# Altium schematic sheet Capybara.SchDoc
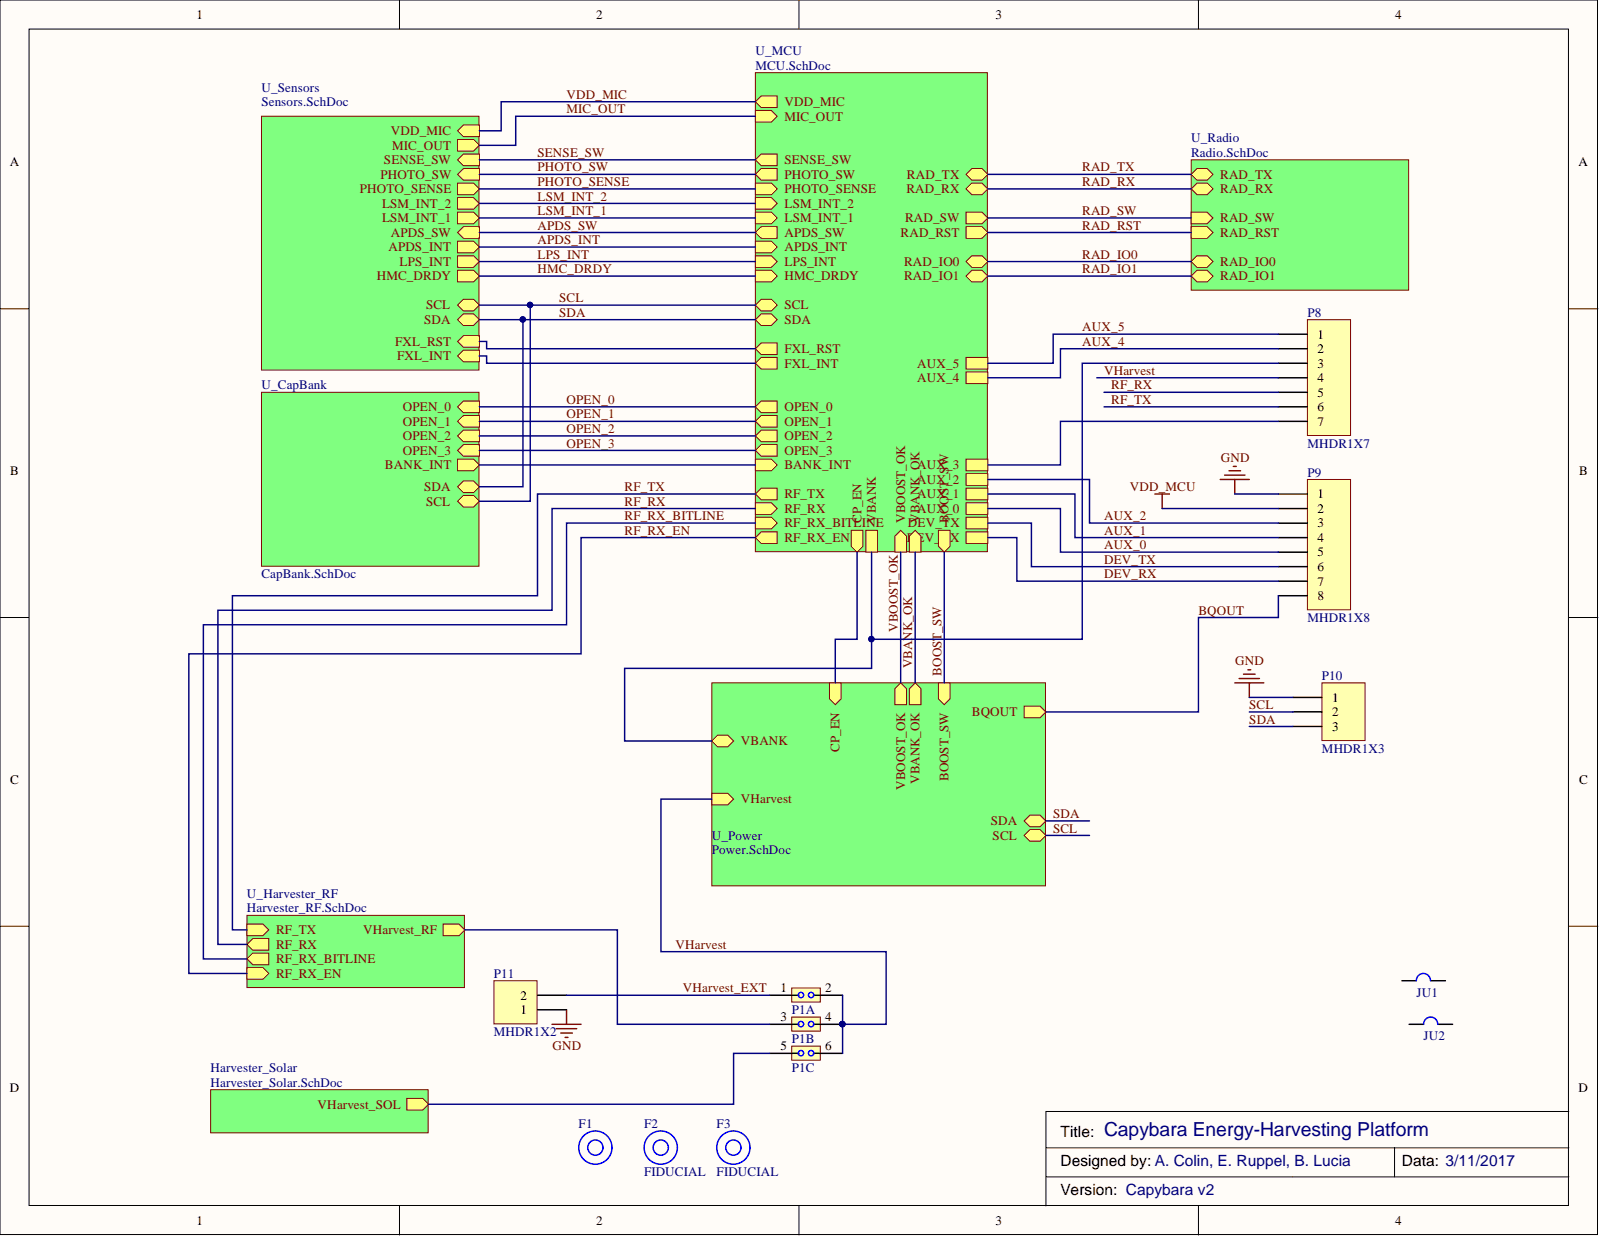
[diagram p0: Capybara.SchDoc]

## Parts
F1  FIDUCIAL  [FIDUCIAL]
F2  FIDUCIAL  [FIDUCIAL]
F3  FIDUCIAL  [FIDUCIAL]
JU1  JUMPER  [JUMPER0603]  (value not drawn)  (jumper)
JU2  JUMPER  [JUMPER0603]  (value not drawn)  (jumper)
P1  JMPHDR_12  [MHDR2X12]  (value not drawn)  (parts A,B,C)
P8  MHDR1X7  [MHDR1X7]
P9  MHDR1X8  [MHDR1X8]
P10  MHDR1X3  [MHDR1X3]
P11  MHDR1X2  [MHDR1X2]

## Nets
GND: P9.1 P10.1 P11.1
VDD_MCU: P9.2
AUX_0: P9.5
AUX_1: P9.4
AUX_2: P9.3
AUX_4: P8.2
AUX_5: P8.1
BQOUT: P9.8
DEV_RX: P9.7
DEV_TX: P9.6
RF_RX: P8.5
RF_TX: P8.6
SCL: P10.2
SDA: P10.3
VHarvest: P1.2 P1.4 P1.6 P8.4
VHarvest_EXT: P1.1 P11.2
NetJU1_1: JU1.1
NetJU1_2: JU1.2
NetJU2_1: JU2.1
NetJU2_2: JU2.2
NetP1_3: P1.3
NetP1_5: P1.5
NetP8_3: P8.3
NetP8_7: P8.7
APDS_INT:
APDS_SW:
BANK_INT:
BOOST_SW:
CP_EN:
FXL_INT:
FXL_RST:
HMC_DRDY:
LPS_INT:
LSM_INT_1:
LSM_INT_2:
MIC_OUT:
OPEN_0:
OPEN_1:
OPEN_2:
OPEN_3:
PHOTO_SENSE:
PHOTO_SW:
RAD_IO0:
RAD_IO1:
RAD_RST:
RAD_RX:
RAD_SW:
RAD_TX:
RF_RX_BITLINE:
RF_RX_EN:
SENSE_SW:
VBANK_OK:
VBOOST_OK:
VDD_MIC:

## Library data of the parts
P1 = JMPHDR_12: Manufacturer: Sullins Connector Solutions; Manufacturer Part Number: GRPB122VWVN-RC; Component Kind: Standard; Digi-Key Part Number: S9015E-12-ND; Distributor: Digikey; Distributor Part Number: S9015E-12-ND; Library Name: Sprite.SCHLIB
P8 = MHDR1X7: Header, 7-Pin; Manufacturer: Harwin Inc.; Manufacturer Part Number: M52-5000745; Component Kind: Standard; Digi-Key Part Number: 952-3360-ND; Distributor: Mouser; Distributor Part Number: 855-M52-5000745; LatestRevisionDate: 17-Jul-2002; LatestRevisionNote: Re-released for DXP Platform.; Library Name: Miscellaneous Connectors.IntLib; Mouser Part Number: 855-M52-5000745; Publisher: Altium Limited
P9 = MHDR1X8: Header, 8-Pin; Manufacturer: Harwin Inc.; Manufacturer Part Number: M52-5000845; Component Kind: Standard; Digi-Key Part Number: 952-3361-ND; Distributor: Mouser; Distributor Part Number: 855-M52-5000845; LatestRevisionDate: 17-Jul-2002; LatestRevisionNote: Re-released for DXP Platform.; Library Name: Miscellaneous Connectors.IntLib; Mouser Part Number: 855-M52-5000845; Publisher: Altium Limited
P10 = MHDR1X3: Header, 3-Pin; Manufacturer: Harwin Inc.; Manufacturer Part Number: M52-5000345; Component Kind: Standard; Digi-Key Part Number: 952-3357-ND; Distributor: Mouser; Distributor Part Number: 855-M52-5000345; LatestRevisionDate: 17-Jul-2002; LatestRevisionNote: Re-released for DXP Platform.; Library Name: Miscellaneous Connectors.IntLib; Mouser Part Number: 855-M52-5000345; Publisher: Altium Limited
P11 = MHDR1X2: Header, 2-Pin; Manufacturer: Samtec Inc.; Manufacturer Part Number: SLM-102-01-S-S; Component Kind: Standard; Digi-Key Part Number: SAM9931-ND; Distributor: Digikey; Distributor Part Number: SAM9931-ND; LatestRevisionDate: 17-Jul-2002; LatestRevisionNote: Re-released for DXP Platform.; Library Name: Miscellaneous Connectors.IntLib; Publisher: Altium Limited
F1-F3 = FIDUCIAL: Component Kind: Standard; Library Name: Sprite.SCHLIB
JU1 JU2 = JUMPER: Library Name: Sprite.SCHLIB
